# Altium schematic sheet net.SchDoc(net)
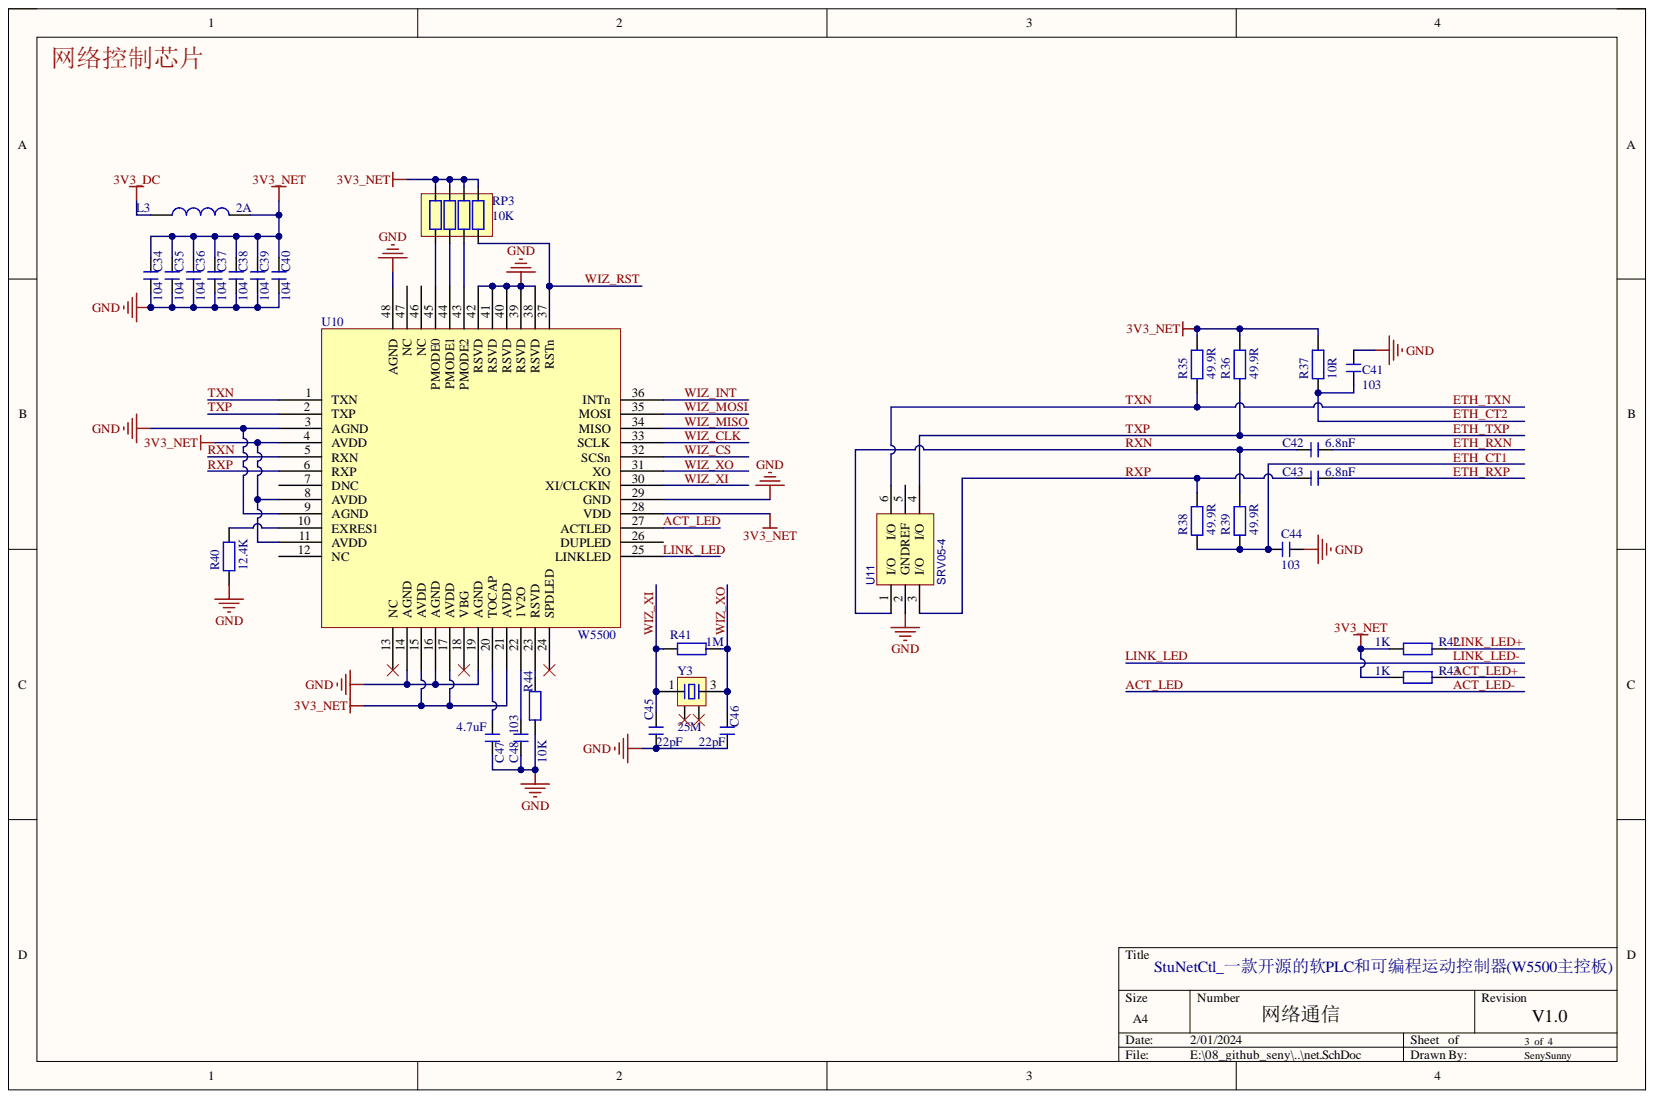
[diagram p0: net.SchDoc]

## Parts
C34  104  [C 0603]
C35  104  [C 0603]
C36  104  [C 0603]
C37  104  [C 0603]
C38  104  [C 0603]
C39  104  [C 0603]
C40  104  [C 0603]
C41  103  [C 0603]
C42  6.8nF  [C 0603]
C43  6.8nF  [C 0603]
C44  103  [C 0603]
C45  22pF  [C 0603]
C46  22pF  [C 0603]
C47  4.7uF  [C 1206]
C48  103  [C 0603]
L3  2A  [L 1206]
R35  49.9R  [R 0603]
R36  49.9R  [R 0603]
R37  10R  [R 0603]
R38  49.9R  [R 0603]
R39  49.9R  [R 0603]
R40  12.4K  [R 0603]
R41  1M  [R 0603]
R42  1K  [R 0603]
R43  1K  [R 0603]
R44  10K  [R 0603]
RP3  10K  [RCA-8P4R-0603]
U10  W5500  [LQFP48 7X7_M]
U11  SRV05-4  [SOT23-6N]
Y3  25M  [XTAL-3225-4P]

## Nets
3V3_DC: L3.1
3V3_NET: C34.1 C35.1 C36.1 C37.1 C38.1 C39.1 C40.1 L3.2 R35.1 R36.1 R37.1 R42.1 R43.1 RP3.1 RP3.2 RP3.3 RP3.4 U10.4(AVDD) U10.8(AVDD) U10.11(AVDD) U10.15(AVDD) U10.17(AVDD) U10.21(AVDD) U10.28(VDD)
GND: C34.2 C35.2 C36.2 C37.2 C38.2 C39.2 C40.2 C41.2 C44.1 C45.1 C46.1 C47.2 C48.2 R40.2 R44.2 U10.3(AGND) U10.9(AGND) U10.14(AGND) U10.16(AGND) U10.19(AGND) U10.29(GND) U10.38(RSVD) U10.39(RSVD) U10.40(RSVD) U10.41(RSVD) U10.42(RSVD) U10.48(AGND) U11.2(GND)
ACT_LED: U10.27(ACTLED)
ACT_LED+: R43.2
ETH_CT1: C44.2 R38.2 R39.2
ETH_CT2: C41.1 R37.2
ETH_RXN: C42.1
ETH_RXP: C43.1
ETH_TXN: R35.2 U10.1(TXN) U11.6(I/O)
ETH_TXP: R36.2 U10.2(TXP) U11.4(I/O)
LINK_LED: U10.25(LINKLED)
LINK_LED+: R42.2
RXN: C42.2 R39.1 U10.5(RXN) U11.1(I/O)
RXP: C43.2 R38.1 U10.6(RXP) U11.3(I/O)
WIZ_CLK: U10.33(SCLK)
WIZ_CS: U10.32(SCSn)
WIZ_INT: U10.36(INTn)
WIZ_MISO: U10.34(MISO)
WIZ_MOSI: U10.35(MOSI)
WIZ_RST: RP3.8 U10.37(RSTn)
WIZ_XI: C45.2 R41.2 U10.30(XI/CLCKIN) Y3.1
WIZ_XO: C46.2 R41.1 U10.31(XO) Y3.3
NetC47_1: C47.1 U10.20(TOCAP)
NetC48_1: C48.1 U10.22(1V2O)
NetR40_1: R40.1 U10.10(EXRES1)
NetR44_1: R44.1 U10.23(RSVD)
NetRP3_5: RP3.5 U10.45(PMODE0)
NetRP3_6: RP3.6 U10.44(PMODE1)
NetRP3_7: RP3.7 U10.43(PMODE2)

Not connected: U10 7(DNC) 12(NC) 13(NC) 18(VBG) 24(SPDLED) 26(DUPLED) 46(NC) 47(NC); U11 5(REF); Y3 2 4

## Library data of the parts
C34-C48 = C: 无极性贴片电容; 版权归属: 源创客; BOM名称: 无极性贴片电容; Component Kind: Standard; 学习资源: www.ooocke.com; Library Name: zblib_v1.IntLib
L3 = L: 小功率贴片电感; 版权归属: 源创客; BOM名称: 小功率贴片电感; Component Kind: Standard; 学习资源: www.ooocke.com; Library Name: zblib_v1.IntLib
R35-R44 = R: 贴片电阻; 版权归属: 源创客; BOM名称: 贴片电阻; Component Kind: Standard; 学习资源: www.ooocke.com; Library Name: zblib_v1.IntLib
RP3 = R-8P4R: 贴片网络排阻; 版权归属: 源创客; BOM名称: 贴片网络排阻; Component Kind: Standard; 学习资源: www.ooocke.com; Library Name: zblib_v1.IntLib
U10 = W5500: 以太网芯片; Component Kind: Standard; ComponentLink1Description: 供应商链接; ComponentLink1URL: http://www.szlcsc.com/product/details_33808.html; Package: LQFP-48_7x7x05P; Supplier: LC; SuppliersPartNumber: C32843; Library Name: zblib_v1.IntLib
U11 = SRV05: TVS二极管/SRV05-4; BOM_Manufacturer: Leiditech; Contributor: LCSC; BOM_Manufacturer Part: SRV05-4; BOM_Supplier: LCSC; BOM_Supplier Part: C384887; Component Kind: Standard; spicePre: D; Library Name: zblib_v1.IntLib
Y3 = XTAL-4: 4脚无源晶振; BOM名称: 4脚晶振; 版权归属: 源创客; Component Kind: Standard; 学习资源: www.ooocke.com; Library Name: zblib_v1.IntLib
